# Altium schematic sheet single_channel.SchDoc(single_channel)
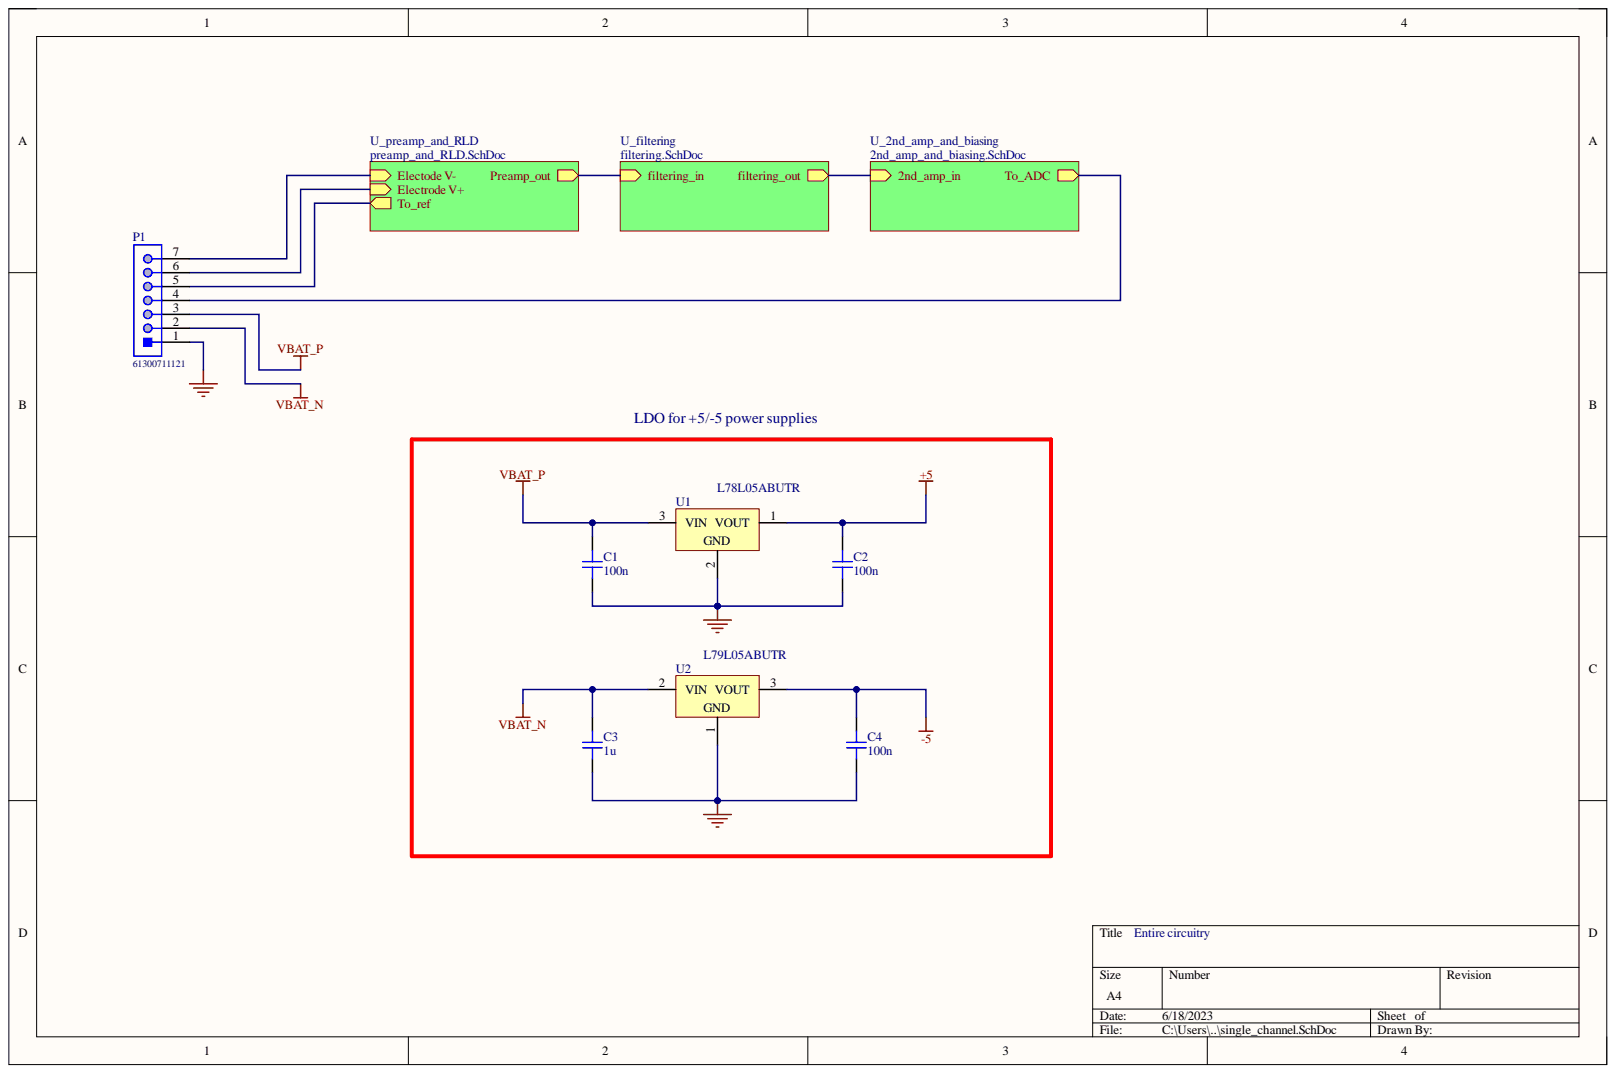
[diagram p0: single_channel.SchDoc]

## Parts
C1  100n  [CAP_0603]
C2  100n  [CAP_0603]
C3  1u  [CAP_0603]
C4  100n  [CAP_0603]
P1  61300711121  [61300711121]
U1  L78L05ABUTR  [SOT-89_N]
U2  L79L05ABUTR  [SOT-89_L]

## Nets
+5: C2.2 U1.1
-5: C4.2 U2.3
GND: C1.1 C2.1 C3.1 C4.1 P1.1 U1.2 U2.1
VBAT_N: C3.2 P1.2 U2.2
VBAT_P: C1.2 P1.3 U1.3
NetP1_4: P1.4
NetP1_5: P1.5
NetP1_6: P1.6
NetP1_7: P1.7
2nd_amp_in:
filtering_in:

## Library data of the parts
C1-C4 = CAP_0603_16V: Capacitor SMD 0603 16V; Component Kind: Standard; Library Name: Components-Schematic.SchLib
P1 = CMP-1502-01074-1: THT Vertical Pin Header WR-PHD, Pitch 2.54 mm, Single Row, 7 pins; Manufacturer: Wurth Elektronik; Contact Resistance: 20mOhm; Gender: Male; Pin Number: 7; Pitch: 2.54mm; Rated Current: 3A; Withstanding Voltage: 500VAC; Working Voltage: 250VAC; Component Kind: Standard; Datasheet URL: https://katalog.we-online.de/em/datasheet/6130xx11121.pdf; Library Name: Altium Content Vault; Manufacturer URL: http://www.we-online.de; Mounting Technology: THT; Operating Temperature: -40to105degC; Orientation: Vertical; Package Reference: 61300711121; Packaging: Bag; Part Number: 61300711121; Publisher: WurthElektronik; Row Number: Single
U1 = CMP-0244-00406-1: Positive Voltage Regulator, 5V, 4-Pin SOT-89, Tape and Reel; Component Kind: Standard; ComponentLink1Description: Manufacturer URL; ComponentLink1URL: http://www.st.com; ComponentLink2Description: Datasheet; ComponentLink2URL: http://www.st.com/internet/com/TECHNICAL_RESOURCES/TECHNICAL_LITERATURE/DATASHEET/CD00000446.pdf; DatasheetVersion: 1/02/2011; Library Name: Altium Content Vault; PackageDescription: 3-Pin SOT-89 Package 1.5 mm Pitch, 4.1 mm Lead Span; PackageReference: SOT-89; PackageVersion: 2011
U2 = CMP-0244-00397-1: Negative Voltage Regulator, -5V, 4-Pin SOT-89, Tape and Reel; Component Kind: Standard; ComponentLink1Description: Manufacturer URL; ComponentLink1URL: http://www.st.com; ComponentLink2Description: Datasheet; ComponentLink2URL: http://www.st.com/internet/com/TECHNICAL_RESOURCES/TECHNICAL_LITERATURE/DATASHEET/CD00000539.pdf; DatasheetVersion: 1/07/2009; Library Name: Altium Content Vault; PackageDescription: 3-Pin SOT-89 Package 1.5 mm Pitch, 4.1 mm Lead Span; PackageReference: SOT-89; PackageVersion: 2011
